# Altium schematic sheet UniBoard.SchDoc
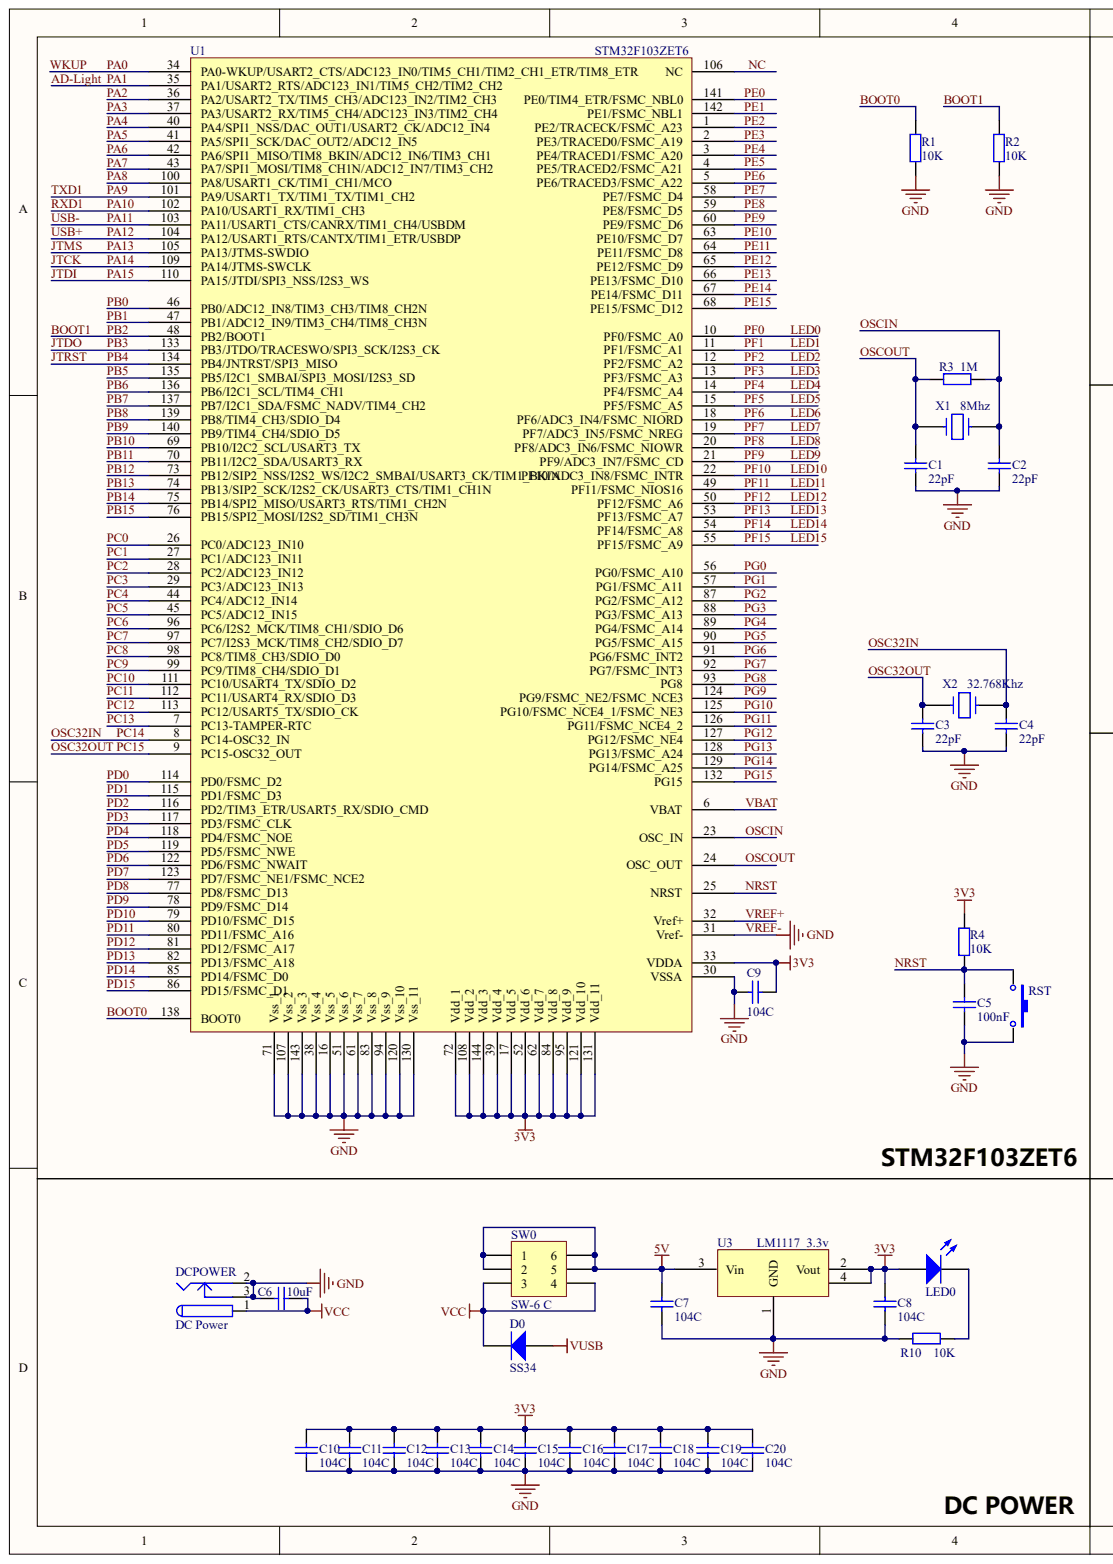
[diagram p0: UniBoard.SchDoc, left]
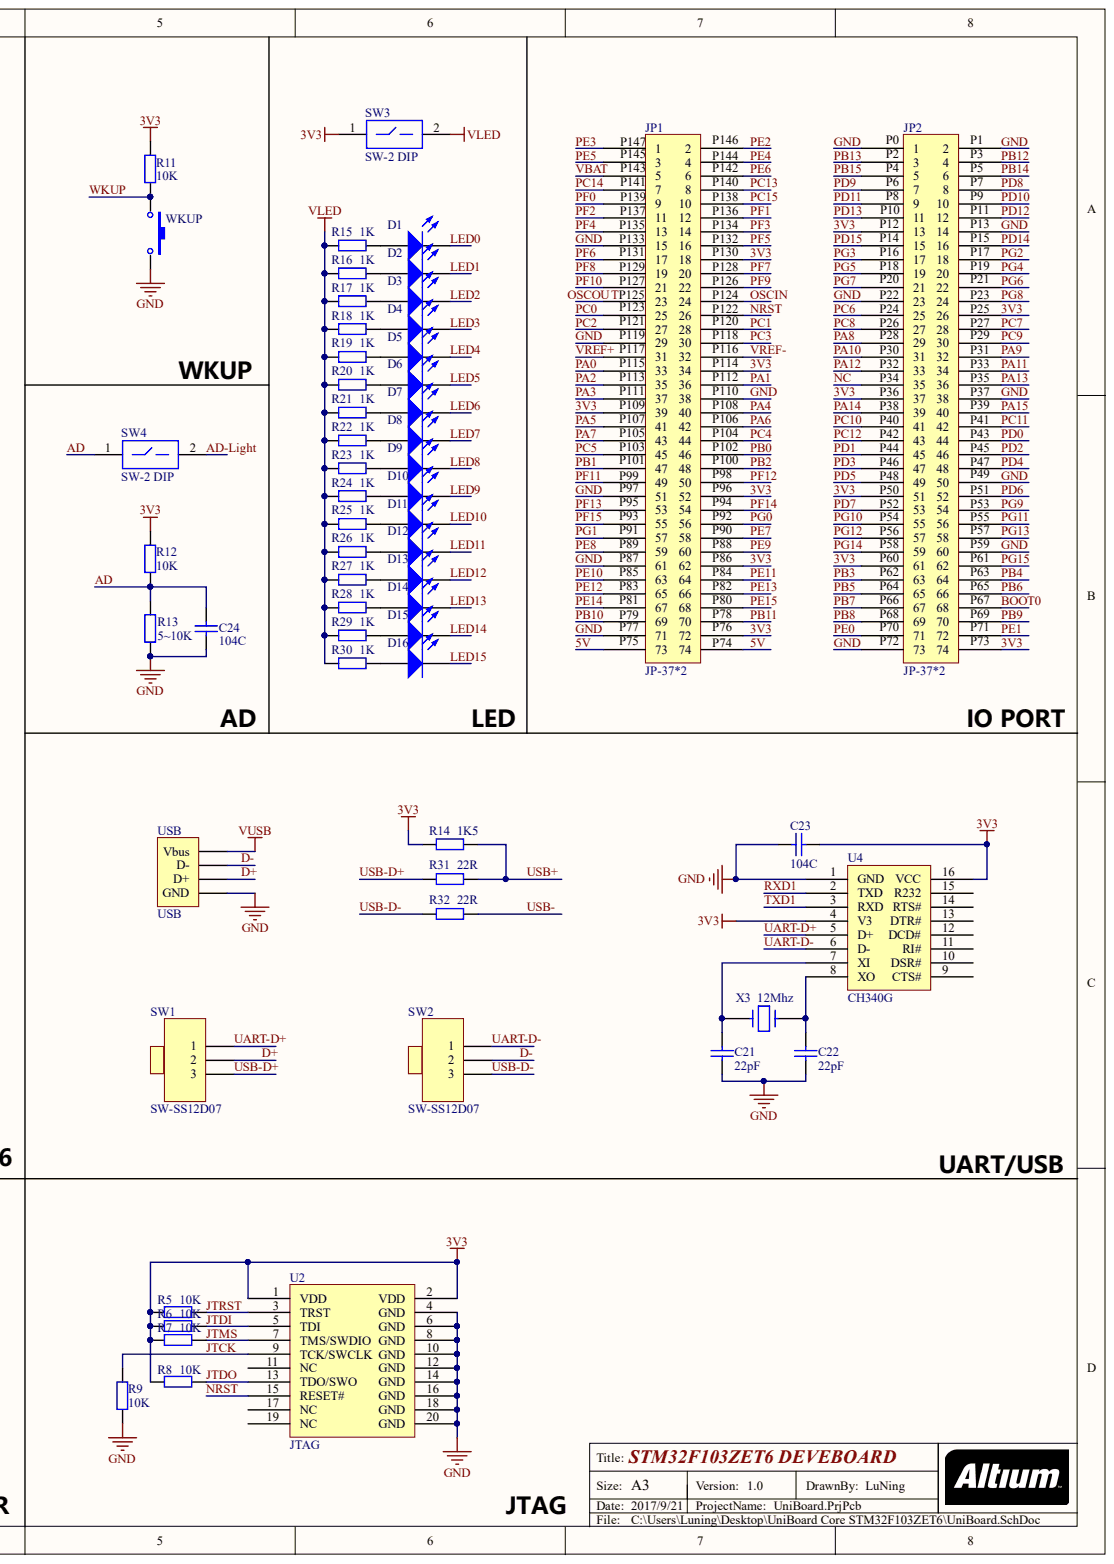
[diagram p1: UniBoard.SchDoc, right]

## Parts
C1  value 22pF  [C-RAD-0805]  (hidden comment: Cap)
C2  value 22pF  [C-RAD-0805]  (hidden comment: Cap)
C3  value 22pF  [C-RAD-0805]  (hidden comment: Cap)
C4  value 22pF  [C-RAD-0805]  (hidden comment: Cap)
C5  value 100nF  [C-RAD-0805]  (hidden comment: Cap)
C6  value 10uF  [C-RAD-0805]  (hidden comment: Cap)
C7  value 104C  [C-RAD-0805]  (hidden comment: Cap)
C8  value 104C  [C-RAD-0805]  (hidden comment: Cap)
C9  value 104C  [C-RAD-0805]  (hidden comment: Cap)
C10  value 104C  [C-RAD-0805]  (hidden comment: Cap)
C11  value 104C  [C-RAD-0805]  (hidden comment: Cap)
C12  value 104C  [C-RAD-0805]  (hidden comment: Cap)
C13  value 104C  [C-RAD-0805]  (hidden comment: Cap)
C14  value 104C  [C-RAD-0805]  (hidden comment: Cap)
C15  value 104C  [C-RAD-0805]  (hidden comment: Cap)
C16  value 104C  [C-RAD-0805]  (hidden comment: Cap)
C17  value 104C  [C-RAD-0805]  (hidden comment: Cap)
C18  value 104C  [C-RAD-0805]  (hidden comment: Cap)
C19  value 104C  [C-RAD-0805]  (hidden comment: Cap)
C20  value 104C  [C-RAD-0805]  (hidden comment: Cap)
C21  value 22pF  [C-RAD-0805]  (hidden comment: Cap)
C22  value 22pF  [C-RAD-0805]  (hidden comment: Cap)
C23  value 104C  [C-RAD-0805]  (hidden comment: Cap)
C24  value 104C  [C-RAD-0805]  (hidden comment: Cap)
D0  SS34  [DIODE-SMB]
D1  LED  [LED_0805]
D2  LED  [LED_0805]
D3  LED  [LED_0805]
D4  LED  [LED_0805]
D5  LED  [LED_0805]
D6  LED  [LED_0805]
D7  LED  [LED_0805]
D8  LED  [LED_0805]
D9  LED  [LED_0805]
D10  LED  [LED_0805]
D11  LED  [LED_0805]
D12  LED  [LED_0805]
D13  LED  [LED_0805]
D14  LED  [LED_0805]
D15  LED  [LED_0805]
D16  LED  [LED_0805]
DCPOWER  DC Power  [DC-005]
JP1  JP-37*2  [SIP74- 2]
JP2  JP-37*2  [SIP74- 2]
LED0  LED  [LED_0805]
R1  value 10K  [0805]  (hidden comment: resistor)
R2  value 10K  [0805]  (hidden comment: resistor)
R3  value 1M  [0805]  (hidden comment: resistor)
R4  value 10K  [0805]  (hidden comment: resistor)
R5  value 10K  [0805]  (hidden comment: resistor)
R6  value 10K  [0805]  (hidden comment: resistor)
R7  value 10K  [0805]  (hidden comment: resistor)
R8  value 10K  [0805]  (hidden comment: resistor)
R9  value 10K  [0805]  (hidden comment: resistor)
R10  value 10K  [0805]  (hidden comment: resistor)
R11  value 10K  [0805]  (hidden comment: resistor)
R12  value 10K  [0805]  (hidden comment: resistor)
R13  value 5~10K  [Res-Light]  (hidden comment: resistor -light)
R14  value 1K5  [0805]  (hidden comment: resistor)
R15  value 1K  [0805]  (hidden comment: resistor)
R16  value 1K  [0805]  (hidden comment: resistor)
R17  value 1K  [0805]  (hidden comment: resistor)
R18  value 1K  [0805]  (hidden comment: resistor)
R19  value 1K  [0805]  (hidden comment: resistor)
R20  value 1K  [0805]  (hidden comment: resistor)
R21  value 1K  [0805]  (hidden comment: resistor)
R22  value 1K  [0805]  (hidden comment: resistor)
R23  value 1K  [0805]  (hidden comment: resistor)
R24  value 1K  [0805]  (hidden comment: resistor)
R25  value 1K  [0805]  (hidden comment: resistor)
R26  value 1K  [0805]  (hidden comment: resistor)
R27  value 1K  [0805]  (hidden comment: resistor)
R28  value 1K  [0805]  (hidden comment: resistor)
R29  value 1K  [0805]  (hidden comment: resistor)
R30  value 1K  [0805]  (hidden comment: resistor)
R31  value 22R  [0805]  (hidden comment: resistor)
R32  value 22R  [0805]  (hidden comment: resistor)
RST  Button -4  [SW-4]  (value not drawn)
SW0  SW-6 C  [六脚自锁开关]
SW1  SW-SS12D07  [SS12D07]
SW2  SW-SS12D07  [SS12D07]
SW3  SW-2 DIP  [SW-2 DIP]
SW4  SW-2 DIP  [SW-2 DIP]
U1  STM32F103ZET6  [STM-LQFP144_N]
U2  JTAG  [20PIN2]
U3  LM1117_3.3v  [SOT223]
U4  CH340G  [SOP-16]
USB  USB  [USBNEW]
WKUP  Button -4  [SW-4]  (value not drawn)
X1  value 8Mhz  [XTAL]  (hidden comment: 16MHz)
X2  value 32.768Khz  [XTAL 32K768]  (hidden comment: 16MHz)
X3  value 12Mhz  [XTAL]  (hidden comment: 16MHz)

## Nets
VCC: C6.1 D0.2 DCPOWER.1 SW0.3 SW0.4
VLED: R15.1 R16.1 R17.1 R18.1 R19.1 R20.1 R21.1 R22.1 R23.1 R24.1 R25.1 R26.1 R27.1 R28.1 R29.1 R30.1 SW3.2
VUSB: D0.1 USB.Vbus
3V3: C8.1 C9.2 C10.2 C11.2 C12.2 C13.2 C14.2 C15.2 C16.2 C17.2 C18.2 C19.2 C20.2 C23.1 JP1.18 JP1.34 JP1.39 JP1.52 JP1.62 JP1.72 JP2.13 JP2.26 JP2.37 JP2.51 JP2.61 JP2.74 LED0.1 R4.2 R5.2 R6.2 R7.2 R8.2 R11.2 R12.2 R14.2 SW3.1 U1.17 U1.33 U1.39 U1.52 U1.62 U1.72 U1.84 U1.95 U1.108 U1.121 U1.131 U1.144 U2.1 U2.2 U3.2 U3.4 U4.4 U4.16
5V: C7.1 JP1.73 JP1.74 SW0.1 SW0.2 SW0.5 SW0.6 U3.3
AD: C24.1 R12.1 R13.2 SW4.1
AD-LIGHT: JP1.36 SW4.2 U1.35
BOOT0: JP2.68 R1.2 U1.138
BOOT1: JP1.48 R2.2 U1.48
D+: SW1.2 USB.D+
D-: SW2.2 USB.D-
GND: C1.1 C2.1 C3.1 C4.1 C5.1 C6.2 C7.2 C8.2 C9.1 C10.1 C11.1 C12.1 C13.1 C14.1 C15.1 C16.1 C17.1 C18.1 C19.1 C20.1 C21.1 C22.1 C23.2 C24.2 DCPOWER.2 DCPOWER.3 JP1.15 JP1.29 JP1.32 JP1.38 JP1.51 JP1.61 JP1.71 JP2.1 JP2.2 JP2.14 JP2.23 JP2.38 JP2.50 JP2.60 JP2.73 R1.1 R2.1 R9.1 R10.2 R13.1 RST.2 U1.16 U1.30 U1.31 U1.38 U1.51 U1.61 U1.71 U1.83 U1.94 U1.107 U1.120 U1.130 U1.143 U2.4 U2.6 U2.8 U2.10 U2.12 U2.14 U2.16 U2.18 U2.20 U3.1 U4.1 USB.GND WKUP.2
JTCK: JP2.39 R9.2 U1.109 U2.9
JTDI: JP2.40 R6.1 U1.110 U2.5
JTDO: JP2.63 R8.1 U1.133 U2.13
JTMS: JP2.36 R7.1 U1.105 U2.7
JTRST: JP2.64 R5.1 U1.134 U2.3
LED0: D1.2 JP1.9 U1.10
LED1: D2.2 JP1.12 U1.11
LED2: D3.2 JP1.11 U1.12
LED3: D4.2 JP1.14 U1.13
LED4: D5.2 JP1.13 U1.14
LED5: D6.2 JP1.16 U1.15
LED6: D7.2 JP1.17 U1.18
LED7: D8.2 JP1.20 U1.19
LED8: D9.2 JP1.19 U1.20
LED9: D10.2 JP1.22 U1.21
LED10: D11.2 JP1.21 U1.22
LED11: D12.2 JP1.49 U1.49
LED12: D13.2 JP1.50 U1.50
LED13: D14.2 JP1.53 U1.53
LED14: D15.2 JP1.54 U1.54
LED15: D16.2 JP1.55 U1.55
NC: JP2.35 U1.106
NRST: C5.2 JP1.26 R4.1 RST.1 U1.25 U2.15
OSC32IN: C4.2 JP1.7 U1.8 X2.2
OSC32OUT: C3.2 JP1.10 U1.9 X2.1
OSCIN: C2.2 JP1.24 R3.1 U1.23 X1.2
OSCOUT: C1.2 JP1.23 R3.2 U1.24 X1.1
PA0: JP1.33 R11.1 U1.34 WKUP.1
PA2: JP1.35 U1.36
PA3: JP1.37 U1.37
PA4: JP1.40 U1.40
PA5: JP1.41 U1.41
PA6: JP1.42 U1.42
PA7: JP1.43 U1.43
PA8: JP2.29 U1.100
PA9: JP2.32 U1.101 U4.3
PA10: JP2.31 U1.102 U4.2
PA11: JP2.34 R32.1 U1.103
PA12: JP2.33 R14.1 R31.1 U1.104
PB0: JP1.46 U1.46
PB1: JP1.47 U1.47
PB5: JP2.65 U1.135
PB6: JP2.66 U1.136
PB7: JP2.67 U1.137
PB8: JP2.69 U1.139
PB9: JP2.70 U1.140
PB10: JP1.69 U1.69
PB11: JP1.70 U1.70
PB12: JP2.4 U1.73
PB13: JP2.3 U1.74
PB14: JP2.6 U1.75
PB15: JP2.5 U1.76
PC0: JP1.25 U1.26
PC1: JP1.28 U1.27
PC2: JP1.27 U1.28
PC3: JP1.30 U1.29
PC4: JP1.44 U1.44
PC5: JP1.45 U1.45
PC6: JP2.25 U1.96
PC7: JP2.28 U1.97
PC8: JP2.27 U1.98
PC9: JP2.30 U1.99
PC10: JP2.41 U1.111
PC11: JP2.42 U1.112
PC12: JP2.43 U1.113
PC13: JP1.8 U1.7
PD0: JP2.44 U1.114
PD1: JP2.45 U1.115
PD2: JP2.46 U1.116
PD3: JP2.47 U1.117
PD4: JP2.48 U1.118
PD5: JP2.49 U1.119
PD6: JP2.52 U1.122
PD7: JP2.53 U1.123
PD8: JP2.8 U1.77
PD9: JP2.7 U1.78
PD10: JP2.10 U1.79
PD11: JP2.9 U1.80
PD12: JP2.12 U1.81
PD13: JP2.11 U1.82
PD14: JP2.16 U1.85
PD15: JP2.15 U1.86
PE0: JP2.71 U1.141
PE1: JP2.72 U1.142
PE2: JP1.2 U1.1
PE3: JP1.1 U1.2
PE4: JP1.4 U1.3
PE5: JP1.3 U1.4
PE6: JP1.6 U1.5
PE7: JP1.58 U1.58
PE8: JP1.59 U1.59
PE9: JP1.60 U1.60
PE10: JP1.63 U1.63
PE11: JP1.64 U1.64
PE12: JP1.65 U1.65
PE13: JP1.66 U1.66
PE14: JP1.67 U1.67
PE15: JP1.68 U1.68
PG0: JP1.56 U1.56
PG1: JP1.57 U1.57
PG2: JP2.18 U1.87
PG3: JP2.17 U1.88
PG4: JP2.20 U1.89
PG5: JP2.19 U1.90
PG6: JP2.22 U1.91
PG7: JP2.21 U1.92
PG8: JP2.24 U1.93
PG9: JP2.54 U1.124
PG10: JP2.55 U1.125
PG11: JP2.56 U1.126
PG12: JP2.57 U1.127
PG13: JP2.58 U1.128
PG14: JP2.59 U1.129
PG15: JP2.62 U1.132
UART-D+: SW1.1 U4.5
UART-D-: SW2.1 U4.6
USB-D+: R31.2 SW1.3
USB-D-: R32.2 SW2.3
VBAT: JP1.5 U1.6
VREF+: JP1.31 U1.32
NetC21_2: C21.2 U4.7 X3.1
NetC22_2: C22.2 U4.8 X3.2
NetD1_1: D1.1 R15.2
NetD2_1: D2.1 R16.2
NetD3_1: D3.1 R17.2
NetD4_1: D4.1 R18.2
NetD5_1: D5.1 R19.2
NetD6_1: D6.1 R20.2
NetD7_1: D7.1 R21.2
NetD8_1: D8.1 R22.2
NetD9_1: D9.1 R23.2
NetD10_1: D10.1 R24.2
NetD11_1: D11.1 R25.2
NetD12_1: D12.1 R26.2
NetD13_1: D13.1 R27.2
NetD14_1: D14.1 R28.2
NetD15_1: D15.1 R29.2
NetD16_1: D16.1 R30.2
NetLED0_2: LED0.2 R10.1

Not connected: U2 11 17 19; U4 9 10 11 12 13 14 15

## Library data of the parts
C1-C24 = Cap: 无极性电容 贴片; Component Kind: Standard; LatestRevisionDate: 17-Jul-2002; LatestRevisionNote: Re-released for DXP Platform.; PackageReference: RAD-0.3; Published: 8-Jun-2000; Library Name: Lupin's Library.IntLib; Publisher: Altium Limited
D0 = Diode: 二极管; Component Kind: Standard; Library Name: Lupin's Library.IntLib
D1-D16 LED0 = LED: 发光二极管; Component Kind: Standard; LatestRevisionDate: 08-Jul-2005; LatestRevisionNote: Mech Layer 1 removed.; PackageReference: LED-0; Published: 8-Jun-2000; Library Name: Lupin's Library.IntLib; Publisher: Altium Limited
DCPOWER = DC Power: 电源插座; Component Kind: Standard; Library Name: Lupin's Library.IntLib
JP1 JP2 = JP-37*2: 接线端子; Component Kind: Standard; Library Name: Luning's Library.IntLib
R1-R12 R14-R32 = resistor: 电阻 贴片; Component Kind: Standard; Library Name: Lupin's Library.IntLib
R13 = resistor -light: 光敏电阻; Component Kind: Standard; Library Name: Lupin's Library.IntLib
RST WKUP = Button -4: 微动开关; Component Kind: Standard; Library Name: Lupin's Library.IntLib
SW0 = SW-6 C: 六脚自锁开关; Component Kind: Standard; Library Name: Luning's Library.IntLib
SW1 SW2 = SW SS12D07: 拨动开关; Component Kind: Standard; Library Name: Lupin's Library.IntLib
SW3 SW4 = SW-2 DIP: 拨码开关 2P; Component Kind: Standard; Library Name: Luning's Library.IntLib
U1 = STM32F103ZET6: STM32 ARM-based 32-bit MCU; Component Kind: Standard; Library Name: Lupin's Library.IntLib
U3 = LM1117_3.3v: 稳压 3.3v; Component Kind: Standard; Library Name: Lupin's Library.IntLib
U4 = CH340G: 串口芯片; Component Kind: Standard; Library Name: Lupin's Library.IntLib
USB = USB: USB-B端子; Component Kind: Standard; Library Name: Lupin's Library.IntLib
X1-X3 = CRYSTAL: 晶振; Component Kind: Standard; Library Name: Lupin's Library.IntLib
U2 = JTAG: Component Kind: Standard; Description: JTAG; Library Name: Lupin's Library.IntLib
